# Altium schematic sheet 3ENVR-260701_01.SchDoc
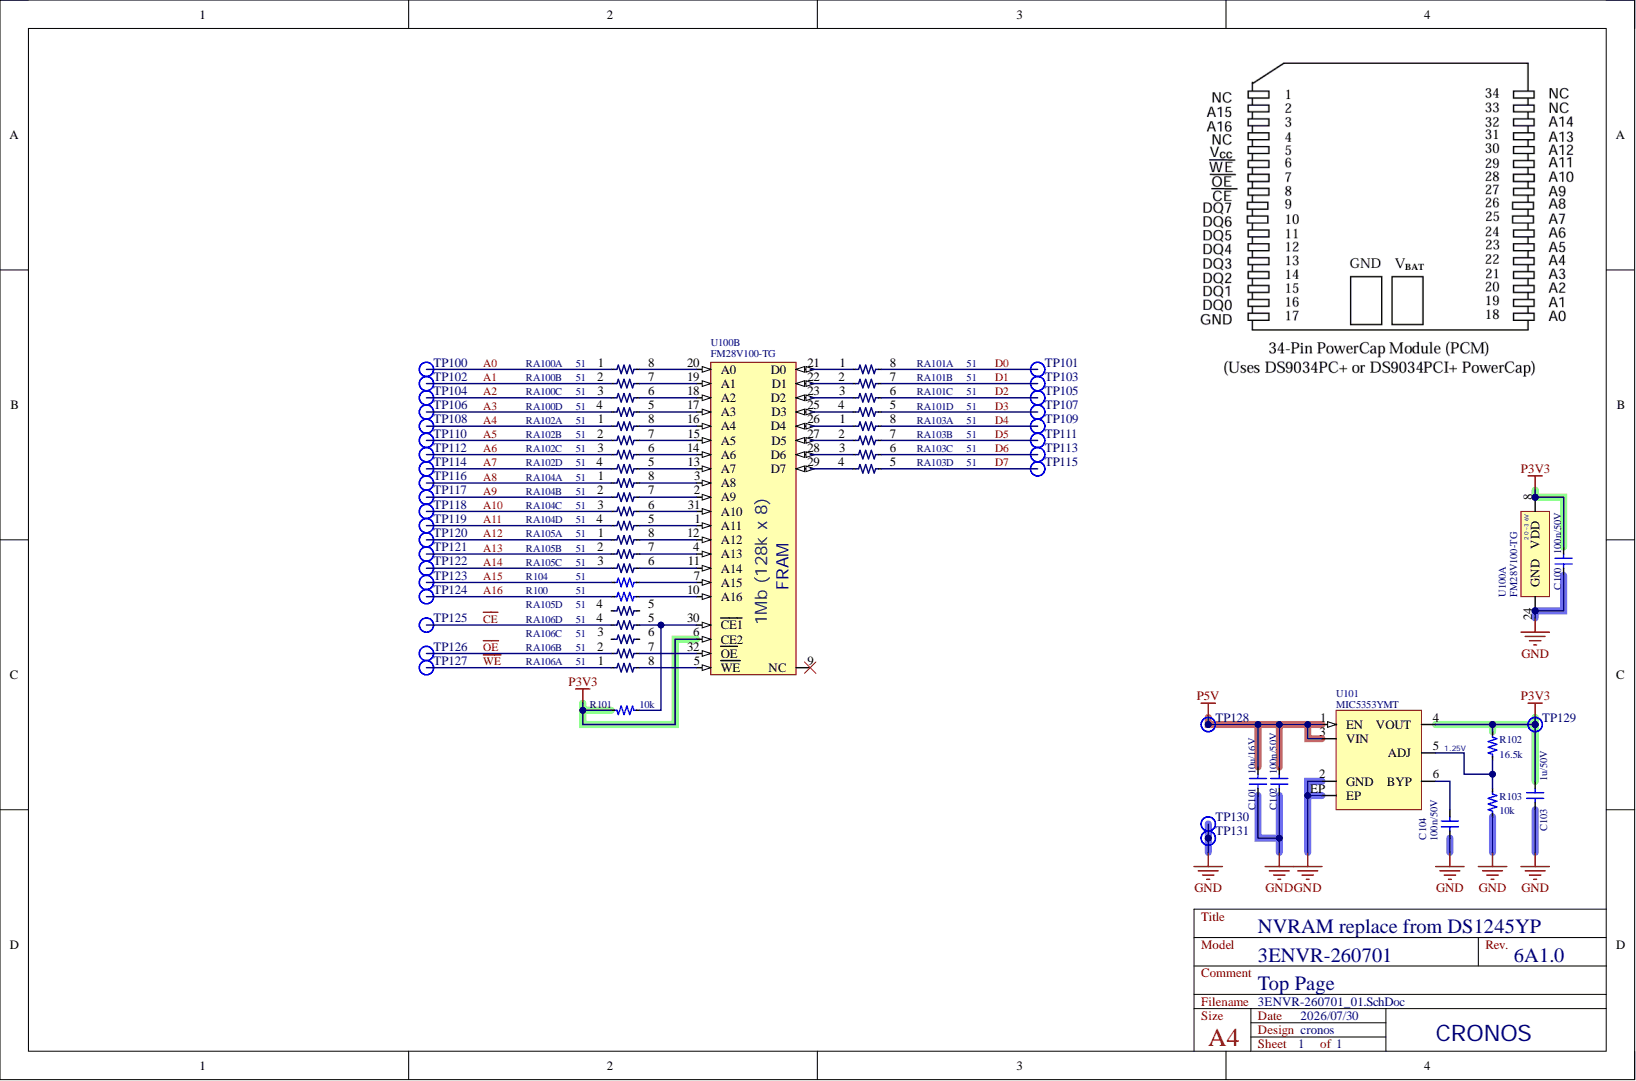
[diagram p0: 3ENVR-260701_01.SchDoc]

## Parts
C100  100n/50V  [SC2-1.00X0.50A]
C101  10u/16V  [SC2-1.60X0.80A]
C102  100n/50V  [SC2-1.00X0.50A]
C103  1u/50V  [SC2-1.60X0.80A]
C104  100n/50V  [SC2-1.00X0.50A]
R100  51  [SR2-1.00X0.50A]
R101  10k  [SR2-1.00X0.50A]
R102  16.5k  [SR2-1.00X0.50A]
R103  10k  [SR2-1.00X0.50A]
R104  51  [SR2-1.00X0.50A]
RA100  51  [WA04X_Walsin]  (parts A,B,C,D)
RA101  51  [WA04X_Walsin]  (parts A,B,C,D)
RA102  51  [WA04X_Walsin]  (parts A,B,C,D)
RA103  51  [WA04X_Walsin]  (parts A,B,C,D)
RA104  51  [WA04X_Walsin]  (parts A,B,C,D)
RA105  51  [WA04X_Walsin]  (parts A,B,C,D)
RA106  51  [WA04X_Walsin]  (parts A,B,C,D)
TP100  (no value)  [TP_0.3]
TP101  (no value)  [TP_0.3]
TP102  (no value)  [TP_0.3]
TP103  (no value)  [TP_0.3]
TP104  (no value)  [TP_0.3]
TP105  (no value)  [TP_0.3]
TP106  (no value)  [TP_0.3]
TP107  (no value)  [TP_0.3]
TP108  (no value)  [TP_0.3]
TP109  (no value)  [TP_0.3]
TP110  (no value)  [TP_0.3]
TP111  (no value)  [TP_0.3]
TP112  (no value)  [TP_0.3]
TP113  (no value)  [TP_0.3]
TP114  (no value)  [TP_0.3]
TP115  (no value)  [TP_0.3]
TP116  (no value)  [TP_0.3]
TP117  (no value)  [TP_0.3]
TP118  (no value)  [TP_0.3]
TP119  (no value)  [TP_0.3]
TP120  (no value)  [TP_0.3]
TP121  (no value)  [TP_0.3]
TP122  (no value)  [TP_0.3]
TP123  (no value)  [TP_0.3]
TP124  (no value)  [TP_0.3]
TP125  (no value)  [TP_0.3]
TP126  (no value)  [TP_0.3]
TP127  (no value)  [TP_0.3]
TP128  (no value)  [TP_0.3]
TP129  (no value)  [TP_1.27_NoMetal]
TP130  (no value)  [TP_0.3]
TP131  (no value)  [TP_1.27_NoMetal]
U100  FM28V100-TG  [FM28V100-TG]  (parts A,B)
U101  MIC5353YMT  [MIC5353]

## Nets
GND: C100.2 C101.1 C102.2 C103.2 C104.2 R103.2 TP130.1 TP131.1 U100.24(GND) U101.2(GND) U101.EP
P3V3: C100.1 C103.1 R101.2 R102.2 TP129.1 U100.6(CE2) U100.8(VDD) U101.4(VOUT)
P5V: C101.2 C102.1 TP128.1 U101.1(EN) U101.3(VIN)
A0: RA100.1 TP100.1
A1: RA100.2 TP102.1
A2: RA100.3 TP104.1
A3: RA100.4 TP106.1
A4: RA102.1 TP108.1
A5: RA102.2 TP110.1
A6: RA102.3 TP112.1
A7: RA102.4 TP114.1
A8: RA104.1 TP116.1
A9: RA104.2 TP117.1
A10: RA104.3 TP118.1
A11: RA104.4 TP119.1
A12: RA105.1 TP120.1
A13: RA105.2 TP121.1
A14: RA105.3 TP122.1
A15: R104.2 TP123.1
A16: R100.2 TP124.1
~{CE}: RA106.4 TP125.1
D0: RA101.8 TP101.1
D1: RA101.7 TP103.1
D2: RA101.6 TP105.1
D3: RA101.5 TP107.1
D4: RA103.8 TP109.1
D5: RA103.7 TP111.1
D6: RA103.6 TP113.1
D7: RA103.5 TP115.1
~{OE}: RA106.2 TP126.1
~{WE}: RA106.1 TP127.1
NetC104_1: C104.1 U101.6(BYP)
NetR100_1: R100.1 U100.10(A16)
NetR101_1: R101.1 RA106.5 U100.30(CE1)
NetR102_1: R102.1 R103.1 U101.5(ADJ)
NetR104_1: R104.1 U100.7(A15)
NetRA100_5: RA100.5 U100.17(A3)
NetRA100_6: RA100.6 U100.18(A2)
NetRA100_7: RA100.7 U100.19(A1)
NetRA100_8: RA100.8 U100.20(A0)
NetRA101_1: RA101.1 U100.21(D0)
NetRA101_2: RA101.2 U100.22(D1)
NetRA101_3: RA101.3 U100.23(D2)
NetRA101_4: RA101.4 U100.25(D3)
NetRA102_5: RA102.5 U100.13(A7)
NetRA102_6: RA102.6 U100.14(A6)
NetRA102_7: RA102.7 U100.15(A5)
NetRA102_8: RA102.8 U100.16(A4)
NetRA103_1: RA103.1 U100.26(D4)
NetRA103_2: RA103.2 U100.27(D5)
NetRA103_3: RA103.3 U100.28(D6)
NetRA103_4: RA103.4 U100.29(D7)
NetRA104_5: RA104.5 U100.1(A11)
NetRA104_6: RA104.6 U100.31(A10)
NetRA104_7: RA104.7 U100.2(A9)
NetRA104_8: RA104.8 U100.3(A8)
NetRA105_6: RA105.6 U100.11(A14)
NetRA105_7: RA105.7 U100.4(A13)
NetRA105_8: RA105.8 U100.12(A12)
NetRA106_7: RA106.7 U100.32(OE)
NetRA106_8: RA106.8 U100.5(WE)

Not connected: RA105 4 5; RA106 3 6; U100 9(NC)

## Library data of the parts
C100 C102 C104 = C: Manufacturer: Murata; Manufacturer Part Number: GRM155R71H104KE14D; Commodity: MLCC; Description 1: Capacitor (non-polar); Replace: OK; Component Kind: Standard; Description: 100n/50V; Footprint(imperial): '0402; Footprint(metric): 1005; Supplier: Digikey; Supplier Part Number: 490-10700-1-ND; Value: 100n/50V; Library Name: I_Lib_cronos_NewLayer.IntLib
C101 = C: 10u, 16V, X5R, 1608Size; Manufacturer: TDK; Manufacturer Part Number: C1608X5R1C106M080AB; Commodity: MLCC; Description 1: Capacitor (non-polar); Replace: OK; Component Kind: Standard; Footprint(imperial): '0603; Footprint(metric): 1608; Supplier: Digikey; Supplier Part Number: 445-9065-1-ND; Value: 10u/16V; Library Name: I_Lib_cronos.IntLib
C103 = C: CAP CER 1UF 50V X7R 0603; Manufacturer: Taiyo Yuden; Manufacturer Part Number: UMK107AB7105KA-T; Commodity: MLCC; Replace: OK; Component Kind: Standard; Footprint(imperial): '0603; Footprint(metric): 1608; Supplier: Digikey; Supplier Part Number: 587-3247-1-ND; Value: 1u/50V; Library Name: I_Lib_cronos_NewLayer.IntLib
R100 R104 = R: RES 51 OHM 1% 1/16W 0402; Manufacturer: Panasonic; Manufacturer Part Number: ERJ-2RKF51R0X; Commodity: Resistor; Replace: OK; Component Kind: Standard; Description 1: Resistor; Footprint(imperial): '0402; Footprint(metric): 1005; Manufacturer2: Yageo; Manufacturer Part Number2: RC0402FR-0751RL; Supplier: Digikey; Supplier Part Number: P51.0LCT-ND; Value: 51; Library Name: I_Lib_cronos_NewLayer.IntLib
R101 = R1005: RES SMD 10k OHM 1% 1/16W 0402; Manufacturer: Panasonic; Manufacturer Part Number: ERJ2RKF1002X; Commodity: Resistor; Replace: OK; Component Kind: Standard; Description 1: Resistor; Footprint(imperial): '0402; Footprint(metric): 1005; Library Name: RCL.SchLib; Supplier: Digikey; Supplier Part Number: 2019-RK73H1ERTTP1002FCT-ND; Value: 10k
R102 = R: RES SMD 16.5K OHM 1% 1/10W 0402; Manufacturer: Panasonic; Manufacturer Part Number: ERJ-2RKF1652X; Commodity: Resistor; Replace: OK; Component Kind: Standard; Description 1: Resistor; Footprint(imperial): '0402; Footprint(metric): 1005; Supplier: Digikey; Supplier Part Number: P16.5KLCT-ND; Value: 16.5k; Library Name: I_Lib_cronos_NewLayer.IntLib
R103 = R: RES SMD 10k OHM 1% 1/16W 0402; Manufacturer: Panasonic; Manufacturer Part Number: ERJ2RKF1002X; Commodity: Resistor; Replace: OK; Component Kind: Standard; Description 1: Resistor; Footprint(imperial): '0402; Footprint(metric): 1005; Supplier: Digikey; Supplier Part Number: 2019-RK73H1ERTTP1002FCT-ND; Value: 10k; Library Name: I_Lib_cronos.IntLib
RA100-RA106 = RA4: Rsisiter Array 4pair 51ohm; Manufacturer: Panasonic; Manufacturer Part Number: EXB-28V510JX; Commodity: Resistor Array; Replace: OK; Component Kind: Standard; Footprint(imperial): 0.079 x 0.039 x 0.022; Footprint(metric): 2.0 x 1.0 x 0.55; Supplier: Digikey; Supplier Part Number: Y7510CT-ND; Value: 51; Library Name: I_Lib_cronos_NewLayer.IntLib
TP100-TP131 = TP: Mounting: NM; Component Kind: Standard; Library Name: I_Lib_cronos_NewLayer.IntLib
U100 = FM28V100-TG: IC FRAM 1MBIT PARALLEL 32TSOP I; Manufacturer: Infineon Technologies; Component Kind: Standard; Manufacturer Part Number: FM28V100-TG; Supplier: Digikey; Supplier Part Number: 448-FM28V100-TG-ND; Value: FM28V100-TG; Library Name: I_Lib_cronos_NewLayer.IntLib
U101 = MIC5353YMT: 2.6 to 6V, 500mA LDO, 1.6x1.6mm; Manufacturer: Micrel; Replace: NG; Commodity: LDO; Component Kind: Standard; Manufacturer Part Number: MIC5353YMT; Value: MIC5353YMT; Library Name: I_Lib_cronos_NewLayer.IntLib
